# Altium schematic sheet EG4S20BG256.SchDoc(EG4S20BG256)
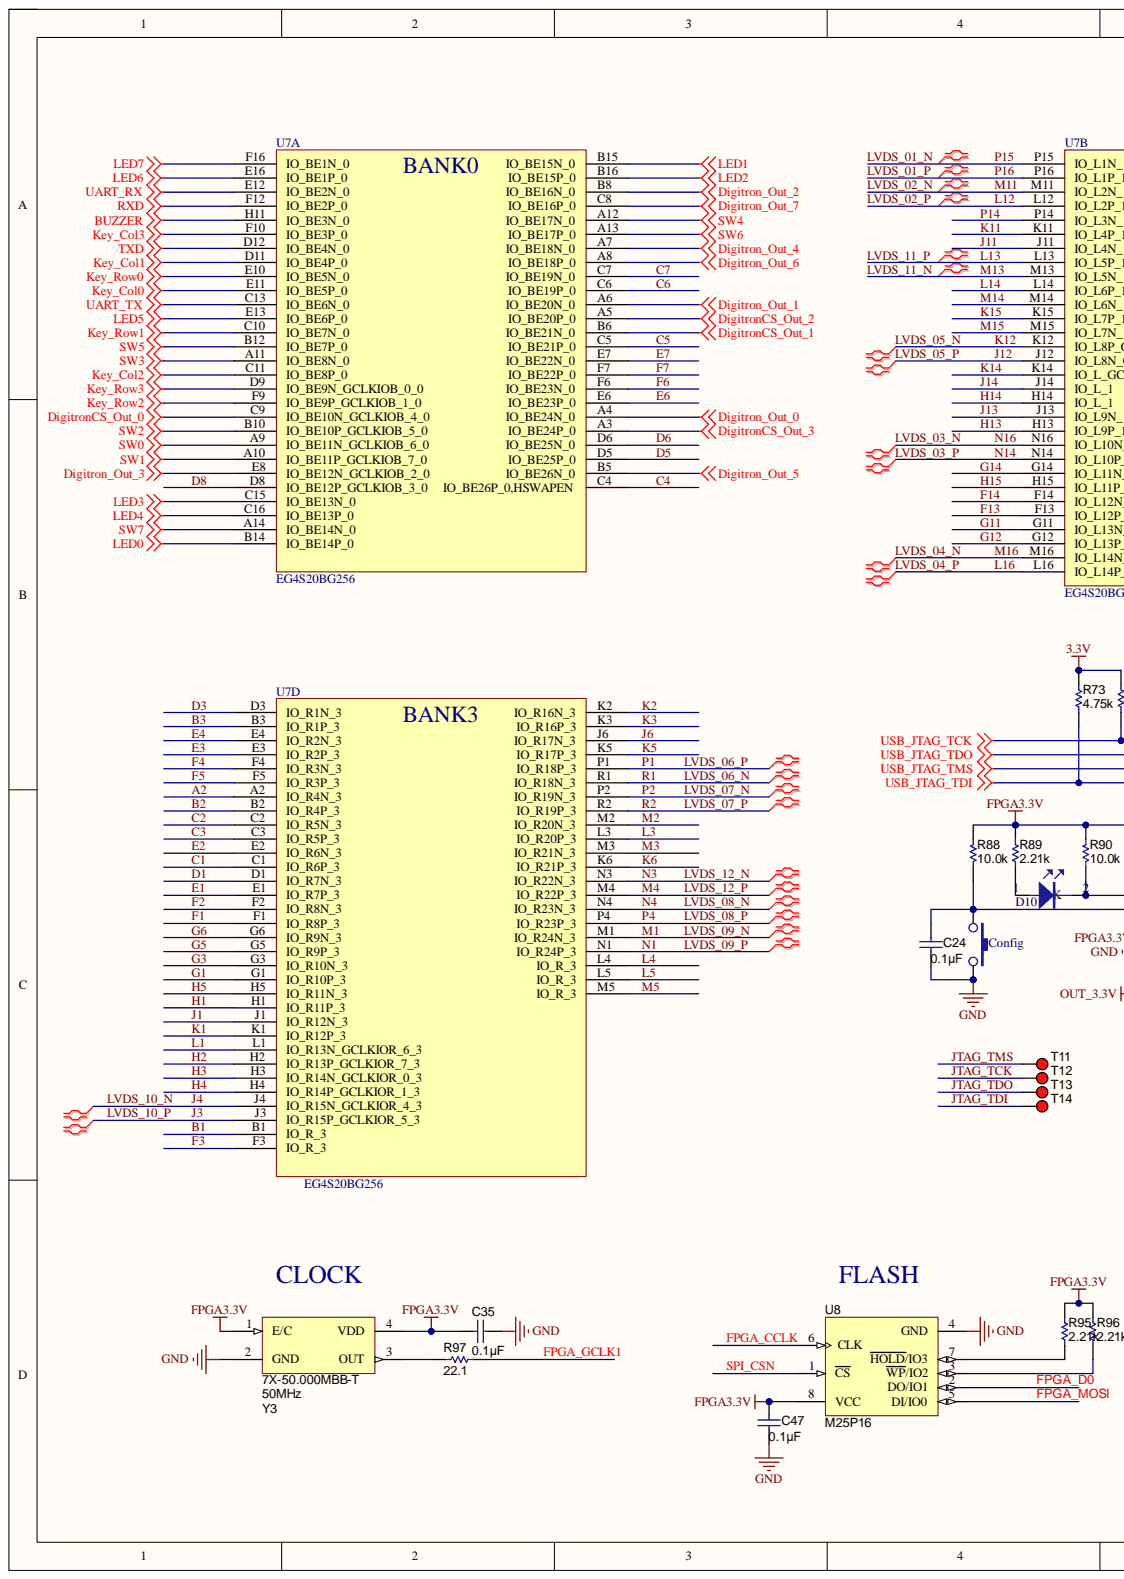
[diagram p0: EG4S20BG256.SchDoc, left]
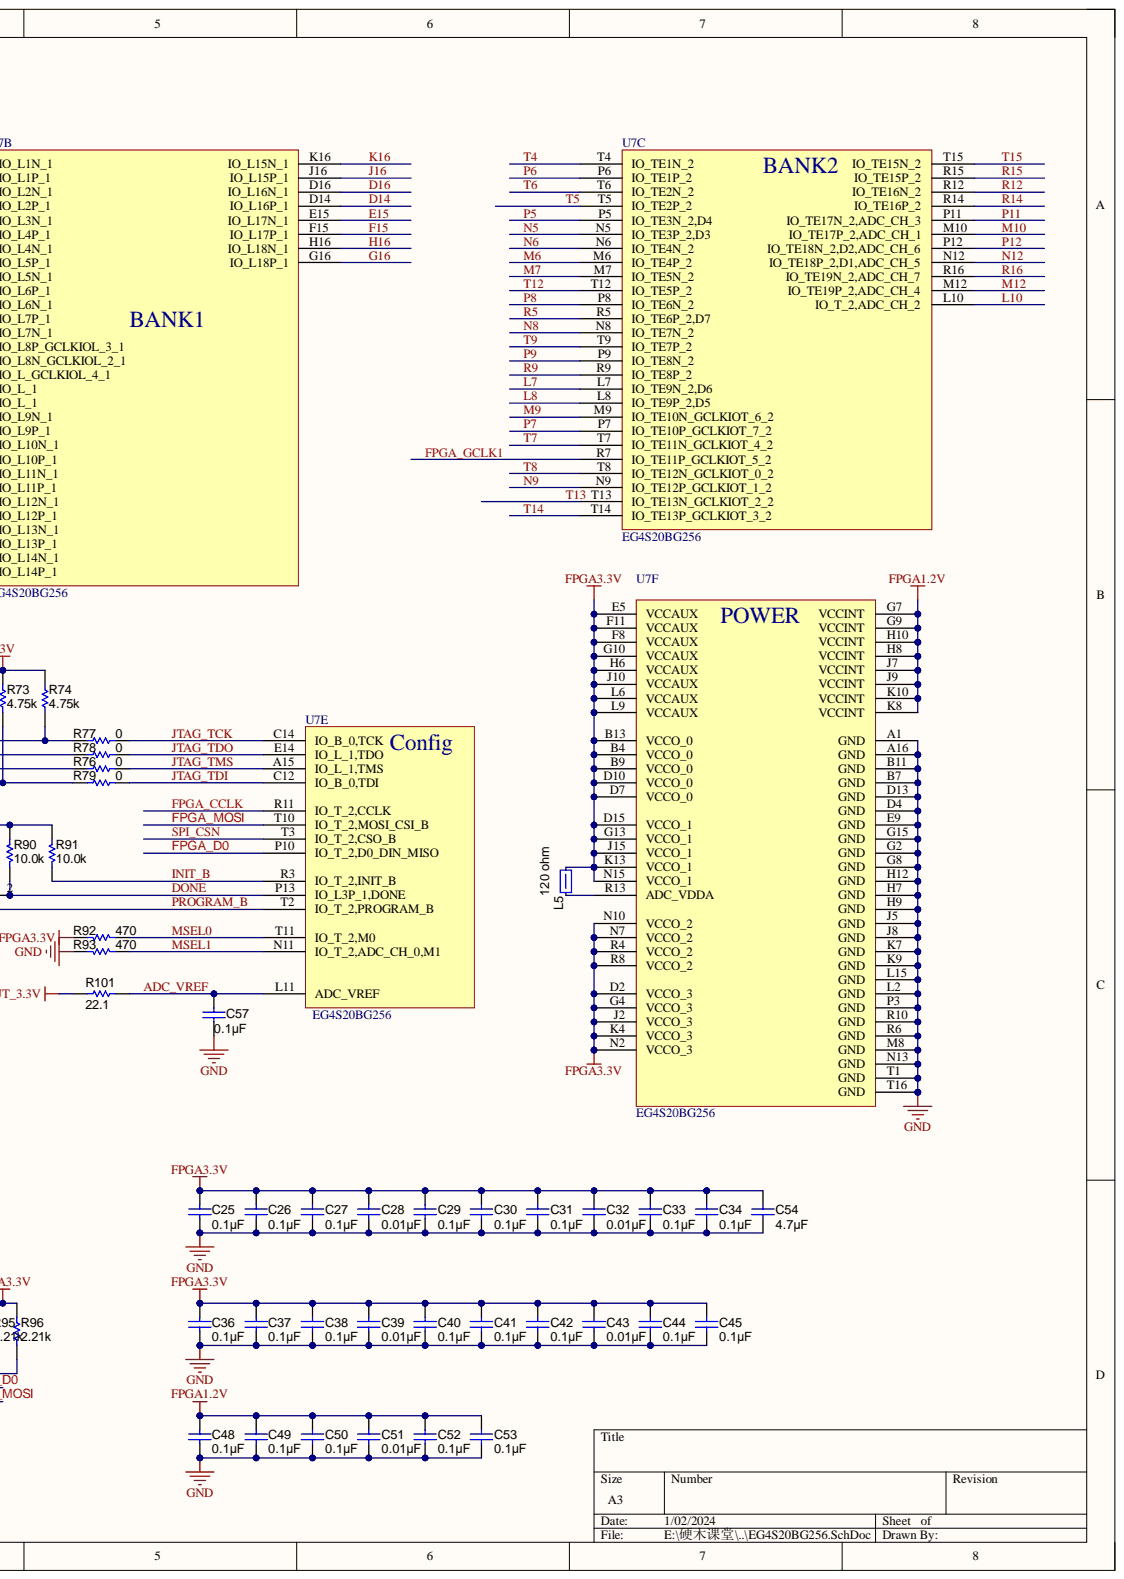
[diagram p1: EG4S20BG256.SchDoc, right]

## Parts
C24  ValueDisplayed: 0.1µF  [0402]  (hidden comment: C1005X7R1H104M)
C25  ValueDisplayed: 0.1μF  [0402]  (hidden comment: C1005X7R1H104M)
C26  ValueDisplayed: 0.1μF  [0402]  (hidden comment: C1005X7R1H104M)
C27  ValueDisplayed: 0.1μF  [0402]  (hidden comment: C1005X7R1H104M)
C28  ValueDisplayed: 0.01μF  [0402]  (hidden comment: C0402C103J5RACTU)
C29  ValueDisplayed: 0.1μF  [0402]  (hidden comment: C1005X7R1H104M)
C30  ValueDisplayed: 0.1μF  [0402]  (hidden comment: C1005X7R1H104M)
C31  ValueDisplayed: 0.1μF  [0402]  (hidden comment: C1005X7R1H104M)
C32  ValueDisplayed: 0.01μF  [0402]  (hidden comment: C0402C103J5RACTU)
C33  ValueDisplayed: 0.1μF  [0402]  (hidden comment: C1005X7R1H104M)
C34  ValueDisplayed: 0.1μF  [0402]  (hidden comment: C1005X7R1H104M)
C35  ValueDisplayed: 0.1µF  [0402]  (hidden comment: C1005X7R1H104M)
C36  ValueDisplayed: 0.1μF  [0402]  (hidden comment: C1005X7R1H104M)
C37  ValueDisplayed: 0.1μF  [0402]  (hidden comment: C1005X7R1H104M)
C38  ValueDisplayed: 0.1μF  [0402]  (hidden comment: C1005X7R1H104M)
C39  ValueDisplayed: 0.01μF  [0402]  (hidden comment: C0402C103J5RACTU)
C40  ValueDisplayed: 0.1μF  [0402]  (hidden comment: C1005X7R1H104M)
C41  ValueDisplayed: 0.1μF  [0402]  (hidden comment: C1005X7R1H104M)
C42  ValueDisplayed: 0.1μF  [0402]  (hidden comment: C1005X7R1H104M)
C43  ValueDisplayed: 0.01μF  [0402]  (hidden comment: C0402C103J5RACTU)
C44  ValueDisplayed: 0.1μF  [0402]  (hidden comment: C1005X7R1H104M)
C45  ValueDisplayed: 0.1μF  [0402]  (hidden comment: C1005X7R1H104M)
C47  ValueDisplayed: 0.1µF  [0402]  (hidden comment: C1005X7R1H104M)
C48  ValueDisplayed: 0.1μF  [0402]  (hidden comment: C1005X7R1H104M)
C49  ValueDisplayed: 0.1μF  [0402]  (hidden comment: C1005X7R1H104M)
C50  ValueDisplayed: 0.1μF  [0402]  (hidden comment: C1005X7R1H104M)
C51  ValueDisplayed: 0.01μF  [0402]  (hidden comment: C0402C103J5RACTU)
C52  ValueDisplayed: 0.1μF  [0402]  (hidden comment: C1005X7R1H104M)
C53  ValueDisplayed: 0.1μF  [0402]  (hidden comment: C1005X7R1H104M)
C54  ValueDisplayed: 4.7µF  [0805_HV]  (hidden comment: GRM21BC81C475KA88L)
C57  ValueDisplayed: 0.1µF  [0402]  (hidden comment: C1005X7R1H104M)
Config  贴片按键  [BUTTOM_T_2]  (value not drawn)
D10  LED 红色  [LED_LTST-C170]  (value not drawn)
L5  value 120 ohm  [0805_HV]  (hidden comment: GZ2012V121TF)
R73  value 4.75k  [0402]  (hidden comment: CRCW04024K75FKED)
R74  value 4.75k  [0402]  (hidden comment: CRCW04024K75FKED)
R76  value 0  [0402]  (hidden comment: CRCW04020000Z0ED)
R77  value 0  [0402]  (hidden comment: CRCW04020000Z0ED)
R78  value 0  [0402]  (hidden comment: CRCW04020000Z0ED)
R79  value 0  [0402]  (hidden comment: CRCW04020000Z0ED)
R88  value 10.0k  [0402]  (hidden comment: CRCW040210K0FKED)
R89  value 2.21k  [0402]  (hidden comment: CRCW04022K21FKED)
R90  value 10.0k  [0402]  (hidden comment: CRCW040210K0FKED)
R91  value 10.0k  [0402]  (hidden comment: CRCW040210K0FKED)
R92  value 470  [0402]  (hidden comment: CRCW0402470RJNED)
R93  value 470  [0402]  (hidden comment: CRCW0402470RJNED)
R95  value 2.21k  [0402]  (hidden comment: CRCW04022K21FKED)
R96  value 2.21k  [0402]  (hidden comment: CRCW04022K21FKED)
R97  value 22.1  Res_ohm: 22.1  [0402]  (hidden comment: CRCW040222R1FKED)
R101  value 22.1  Res_ohm: 22.1  [0402]  (hidden comment: CRCW040222R1FKED)
T11  5000  [TP]  (value not drawn)
T12  5000  [TP]  (value not drawn)
T13  5000  [TP]  (value not drawn)
T14  5000  [TP]  (value not drawn)
U7  EG4S20BG256  [FBGA256]  (parts A,B,C,D,E,F)
U8  M25P16  [MICT-MW-8_N]
Y3  7X-50.000MBB-T  Frequency: 50MHz  [XTAL_NX3225SA]

## Nets
3.3V: R73.2 R74.2
BUZZER: U7.H11(IO_BE3N_0)
Digitron_Out_0: U7.A4(IO_BE24N_0)
Digitron_Out_1: U7.A6(IO_BE20N_0)
Digitron_Out_2: U7.B8(IO_BE16N_0)
Digitron_Out_3: U7.E8(IO_BE12N_GCLKIOB_2_0)
Digitron_Out_4: U7.A7(IO_BE18N_0)
Digitron_Out_5: U7.B5(IO_BE26N_0)
Digitron_Out_6: U7.A8(IO_BE18P_0)
Digitron_Out_7: U7.C8(IO_BE16P_0)
DigitronCS_Out_0: U7.C9(IO_BE10N_GCLKIOB_4_0)
DigitronCS_Out_1: U7.B6(IO_BE21N_0)
DigitronCS_Out_2: U7.A5(IO_BE20P_0)
DigitronCS_Out_3: U7.A3(IO_BE24P_0)
FPGA1.2V: C48.1 C49.1 C50.1 C51.1 C52.1 C53.1 U7.G7(VCCINT) U7.G9(VCCINT) U7.H8(VCCINT) U7.H10(VCCINT) U7.J7(VCCINT) U7.J9(VCCINT) U7.K8(VCCINT) U7.K10(VCCINT)
FPGA3.3V: C25.1 C26.1 C27.1 C28.1 C29.1 C30.1 C31.1 C32.1 C33.1 C34.1 C35.1 C36.1 C37.1 C38.1 C39.1 C40.1 C41.1 C42.1 C43.1 C44.1 C45.1 C47.2 C54.1 L5.2 R88.2 R89.1 R90.2 R91.2 R92.2 R95.1 R96.1 U7.B4(VCCO_0) U7.B9(VCCO_0) U7.B13(VCCO_0) U7.D2(VCCO_3) U7.D7(VCCO_0) U7.D10(VCCO_0) U7.D15(VCCO_1) U7.E5(VCCAUX) U7.F8(VCCAUX) U7.F11(VCCAUX) U7.G4(VCCO_3) U7.G10(VCCAUX) U7.G13(VCCO_1) U7.H6(VCCAUX) U7.J2(VCCO_3) U7.J10(VCCAUX) U7.J15(VCCO_1) U7.K4(VCCO_3) U7.K13(VCCO_1) U7.L6(VCCAUX) U7.L9(VCCAUX) U7.N2(VCCO_3) U7.N7(VCCO_2) U7.N10(VCCO_2) U7.N15(VCCO_1) U7.R4(VCCO_2) U7.R8(VCCO_2) U8.8(VCC) Y3.1(E/C) Y3.4(VDD)
GND: C24.1 C25.2 C26.2 C27.2 C28.2 C29.2 C30.2 C31.2 C32.2 C33.2 C34.2 C35.2 C36.2 C37.2 C38.2 C39.2 C40.2 C41.2 C42.2 C43.2 C44.2 C45.2 C47.1 C48.2 C49.2 C50.2 C51.2 C52.2 C53.2 C54.2 C57.1 Config.2 R93.2 U7.A1(GND) U7.A16(GND) U7.B7(GND) U7.B11(GND) U7.D4(GND) U7.D13(GND) U7.E9(GND) U7.G2(GND) U7.G8(GND) U7.G15(GND) U7.H7(GND) U7.H9(GND) U7.H12(GND) U7.J5(GND) U7.J8(GND) U7.K7(GND) U7.K9(GND) U7.L2(GND) U7.L15(GND) U7.M8(GND) U7.N13(GND) U7.P3(GND) U7.R6(GND) U7.R10(GND) U7.T1(GND) U7.T16(GND) U8.4(GND) Y3.2(GND)
Key_Col0: U7.E11(IO_BE5P_0)
Key_Col1: U7.D11(IO_BE4P_0)
Key_Col2: U7.C11(IO_BE8P_0)
Key_Col3: U7.F10(IO_BE3P_0)
Key_Row0: U7.E10(IO_BE5N_0)
Key_Row1: U7.C10(IO_BE7N_0)
Key_Row2: U7.F9(IO_BE9P_GCLKIOB_1_0)
Key_Row3: U7.D9(IO_BE9N_GCLKIOB_0_0)
LED0: U7.B14(IO_BE14P_0)
LED1: U7.B15(IO_BE15N_0)
LED2: U7.B16(IO_BE15P_0)
LED3: U7.C15(IO_BE13N_0)
LED4: U7.C16(IO_BE13P_0)
LED5: U7.E13(IO_BE6P_0)
LED6: U7.E16(IO_BE1P_0)
LED7: U7.F16(IO_BE1N_0)
OUT_3.3V: R101.2
RXD: U7.F12(IO_BE2P_0)
SW0: U7.A9(IO_BE11N_GCLKIOB_6_0)
SW1: U7.A10(IO_BE11P_GCLKIOB_7_0)
SW2: U7.B10(IO_BE10P_GCLKIOB_5_0)
SW3: U7.A11(IO_BE8N_0)
SW4: U7.A12(IO_BE17N_0)
SW5: U7.B12(IO_BE7P_0)
SW6: U7.A13(IO_BE17P_0)
SW7: U7.A14(IO_BE14N_0)
TXD: U7.D12(IO_BE4N_0)
UART_RX: U7.E12(IO_BE2N_0)
UART_TX: U7.C13(IO_BE6N_0)
USB_JTAG_TCK: R74.1 R77.2
USB_JTAG_TDI: R73.1 R79.2
USB_JTAG_TDO: R78.2
USB_JTAG_TMS: R76.2
A2: U7.A2(IO_R4N_3)
ADC_VREF: C57.2 R101.1 U7.L11(ADC_VREF)
B1: U7.B1(IO_R_3)
B2: U7.B2(IO_R4P_3)
B3: U7.B3(IO_R1P_3)
C1: U7.C1(IO_R6P_3)
C2: U7.C2(IO_R5N_3)
C3: U7.C3(IO_R5P_3)
C4: U7.C4(IO_BE26P_0,HSWAPEN)
C5: U7.C5(IO_BE21P_0)
C6: U7.C6(IO_BE19P_0)
C7: U7.C7(IO_BE19N_0)
D1: U7.D1(IO_R7N_3)
D3: U7.D3(IO_R1N_3)
D5: U7.D5(IO_BE25P_0)
D6: U7.D6(IO_BE25N_0)
D8: U7.D8(IO_BE12P_GCLKIOB_3_0)
D14: U7.D14(IO_L16P_1)
D16: U7.D16(IO_L16N_1)
DONE: D10.2 R90.1 U7.P13(IO_L3P_1,DONE)
E1: U7.E1(IO_R7P_3)
E2: U7.E2(IO_R6N_3)
E3: U7.E3(IO_R2P_3)
E4: U7.E4(IO_R2N_3)
E6: U7.E6(IO_BE23P_0)
E7: U7.E7(IO_BE22N_0)
E15: U7.E15(IO_L17N_1)
F1: U7.F1(IO_R8P_3)
F2: U7.F2(IO_R8N_3)
F3: U7.F3(IO_R_3)
F4: U7.F4(IO_R3N_3)
F5: U7.F5(IO_R3P_3)
F6: U7.F6(IO_BE23N_0)
F7: U7.F7(IO_BE22P_0)
F13: U7.F13(IO_L12P_1)
F14: U7.F14(IO_L12N_1)
F15: U7.F15(IO_L17P_1)
FPGA_CCLK: U7.R11(IO_T_2,CCLK) U8.6(CLK)
FPGA_D0: U7.P10(IO_T_2,D0_DIN_MISO) U8.2(DO/IO1)
FPGA_GCLK1: R97.2 U7.R7(IO_TE11P_GCLKIOT_5_2)
FPGA_MOSI: U7.T10(IO_T_2,MOSI_CSI_B) U8.5(DI/IO0)
G1: U7.G1(IO_R10P_3)
G3: U7.G3(IO_R10N_3)
G5: U7.G5(IO_R9P_3)
G6: U7.G6(IO_R9N_3)
G11: U7.G11(IO_L13N_1)
G12: U7.G12(IO_L13P_1)
G14: U7.G14(IO_L11N_1)
G16: U7.G16(IO_L18P_1)
H1: U7.H1(IO_R11P_3)
H2: U7.H2(IO_R13P_GCLKIOR_7_3)
H3: U7.H3(IO_R14N_GCLKIOR_0_3)
H4: U7.H4(IO_R14P_GCLKIOR_1_3)
H5: U7.H5(IO_R11N_3)
H13: U7.H13(IO_L9P_1)
H14: U7.H14(IO_L_1)
H15: U7.H15(IO_L11P_1)
H16: U7.H16(IO_L18N_1)
INIT_B: R91.1 U7.R3(IO_T_2,INIT_B)
J1: U7.J1(IO_R12N_3)
J3: U7.J3(IO_R15P_GCLKIOR_5_3)
J4: U7.J4(IO_R15N_GCLKIOR_4_3)
J6: U7.J6(IO_R17N_3)
J11: U7.J11(IO_L4N_1)
J12: U7.J12(IO_L8N_GCLKIOL_2_1)
J13: U7.J13(IO_L9N_1)
J14: U7.J14(IO_L_1)
J16: U7.J16(IO_L15P_1)
JTAG_TCK: R77.1 T12.1 U7.C14(IO_B_0,TCK)
JTAG_TDI: R79.1 T14.1 U7.C12(IO_B_0,TDI)
JTAG_TDO: R78.1 T13.1 U7.E14(IO_L_1,TDO)
JTAG_TMS: R76.1 T11.1 U7.A15(IO_L_1,TMS)
K1: U7.K1(IO_R12P_3)
K2: U7.K2(IO_R16N_3)
K3: U7.K3(IO_R16P_3)
K5: U7.K5(IO_R17P_3)
K6: U7.K6(IO_R21P_3)
K11: U7.K11(IO_L4P_1)
K12: U7.K12(IO_L8P_GCLKIOL_3_1)
K14: U7.K14(IO_L_GCLKIOL_4_1)
K15: U7.K15(IO_L7P_1)
K16: U7.K16(IO_L15N_1)
L1: U7.L1(IO_R13N_GCLKIOR_6_3)
L3: U7.L3(IO_R20P_3)
L4: U7.L4(IO_R_3)
L5: U7.L5(IO_R_3)
L7: U7.L7(IO_TE9N_2,D6)
L8: U7.L8(IO_TE9P_2,D5)
L10: U7.L10(IO_T_2,ADC_CH_2)
L12: U7.L12(IO_L2P_1)
L13: U7.L13(IO_L5P_1)
L14: U7.L14(IO_L6P_1)
L16: U7.L16(IO_L14P_1)
LVDS_01_N: U7.P15(IO_L1N_1)
LVDS_01_P: U7.P16(IO_L1P_1)
LVDS_02_N: U7.M11(IO_L2N_1)
LVDS_03_N: U7.N16(IO_L10N_1)
LVDS_03_P: U7.N14(IO_L10P_1)
LVDS_04_N: U7.M16(IO_L14N_1)
LVDS_06_N: U7.R1(IO_R18N_3)
LVDS_06_P: U7.P1(IO_R18P_3)
LVDS_07_N: U7.P2(IO_R19N_3)
LVDS_07_P: U7.R2(IO_R19P_3)
LVDS_08_N: U7.N4(IO_R23N_3)
LVDS_08_P: U7.P4(IO_R23P_3)
LVDS_09_N: U7.M1(IO_R24N_3)
LVDS_09_P: U7.N1(IO_R24P_3)
LVDS_11_N: U7.M13(IO_L5N_1)
LVDS_12_N: U7.N3(IO_R22N_3)
LVDS_12_P: U7.M4(IO_R22P_3)
M2: U7.M2(IO_R20N_3)
M3: U7.M3(IO_R21N_3)
M5: U7.M5(IO_R_3)
M6: U7.M6(IO_TE4P_2)
M7: U7.M7(IO_TE5N_2)
M9: U7.M9(IO_TE10N_GCLKIOT_6_2)
M10: U7.M10(IO_TE17P_2,ADC_CH_1)
M12: U7.M12(IO_TE19P_2,ADC_CH_4)
M14: U7.M14(IO_L6N_1)
M15: U7.M15(IO_L7N_1)
MSEL0: R92.1 U7.T11(IO_T_2,M0)
MSEL1: R93.1 U7.N11(IO_T_2,ADC_CH_0,M1)
N5: U7.N5(IO_TE3P_2,D3)
N6: U7.N6(IO_TE4N_2)
N8: U7.N8(IO_TE7N_2)
N9: U7.N9(IO_TE12P_GCLKIOT_1_2)
N12: U7.N12(IO_TE18P_2,D1,ADC_CH_5)
P5: U7.P5(IO_TE3N_2,D4)
P6: U7.P6(IO_TE1P_2)
P7: U7.P7(IO_TE10P_GCLKIOT_7_2)
P8: U7.P8(IO_TE6N_2)
P9: U7.P9(IO_TE8N_2)
P11: U7.P11(IO_TE17N_2,ADC_CH_3)
P12: U7.P12(IO_TE18N_2,D2,ADC_CH_6)
P14: U7.P14(IO_L3N_1)
PROGRAM_B: C24.2 Config.1 R88.1 U7.T2(IO_T_2,PROGRAM_B)
R5: U7.R5(IO_TE6P_2,D7)
R9: U7.R9(IO_TE8P_2)
R12: U7.R12(IO_TE16N_2)
R14: U7.R14(IO_TE16P_2)
R15: U7.R15(IO_TE15P_2)
R16: U7.R16(IO_TE19N_2,ADC_CH_7)
SPI_CSN: U7.T3(IO_T_2,CSO_B) U8.1(CS)
T4: U7.T4(IO_TE1N_2)
T5: U7.T5(IO_TE2P_2)
T6: U7.T6(IO_TE2N_2)
T7: U7.T7(IO_TE11N_GCLKIOT_4_2)
T8: U7.T8(IO_TE12N_GCLKIOT_0_2)
T9: U7.T9(IO_TE7P_2)
T12: U7.T12(IO_TE5P_2)
T13: U7.T13(IO_TE13N_GCLKIOT_2_2)
T14: U7.T14(IO_TE13P_GCLKIOT_3_2)
T15: U7.T15(IO_TE15N_2)
NetD10_1: D10.1 R89.2
NetL5_1: L5.1 U7.R13(ADC_VDDA)
NetR95_2: R95.2 U8.7(HOLD/IO3)
NetR96_2: R96.2 U8.3(WP/IO2)
NetR97_1: R97.1 Y3.3(OUT)

## Library data of the parts
C24 C35 C47 C57 = C1005X7R1H104M: CAP, CERM, 0.1 µF, 50 V, +/- 20%, X7R, 0402; Manufacturer: TDK; Cap_F: 0.0000001; CompType: Capacitor; Polarized: N; TaMax_C: 125; TaMin_C: -55; Tolerance_%: 20; U/M: EA; Value: 0.1uF; Value1: Unknown; Value2: 50V; VDC_V: 50; Cap_Case: 0402; Component Kind: Standard; ComponentLink1Description: Datasheet link; LatestRevisionDate: 2015-05-02; Library Name: Capacitor_Ceramic.IntLib; MountType: SMT; PackageReference: 0402; PartNumber: C1005X7R1H104M; Published: 2008-06-19; RoHS: Y; Signal Integrity: Cap; Simulation: Cap; Supplier 1: Digi-Key; Supplier 2: Farnell; Supplier Part Number 1: 445-5933-1-ND; Supplier Part Number 2: 1907278; SymbolVersion: 2008-07-09; Technology: Ceramic; TempCo: X7R; Publisher: Texas Instruments
C25-C27 C29-C31 C33 C34 C36-C38 C40-C42 C44 C45 C48-C50 C52 C53 = C1005X7R1H104M: CAP, CERM, 0.1 μF, 50 V, +/- 20%, X7R, 0402; Manufacturer: TDK; Cap_F: 0.0000001; CompType: Capacitor; Polarized: N; TaMax_C: 125; TaMin_C: -55; Tolerance_%: 20; U/M: EA; Value: 0.1uF; Value1: Unknown; Value2: 50V; VDC_V: 50; Cap_Case: 0402; Component Kind: Standard; ComponentLink1Description: Datasheet link; LatestRevisionDate: 2015-05-02; Library Name: Capacitor_Ceramic.IntLib; MountType: SMT; PackageReference: 0402; PartNumber: C1005X7R1H104M; Published: 2008-06-19; RoHS: Y; Signal Integrity: Cap; Simulation: Cap; Supplier 1: Digi-Key; Supplier 2: Farnell; Supplier Part Number 1: 445-5933-1-ND; Supplier Part Number 2: 1907278; SymbolVersion: 2008-07-09; Technology: Ceramic; TempCo: X7R; Publisher: Texas Instruments
C28 C32 C39 C43 C51 = C0402C103J5RACTU: CAP, CERM, 0.01 μF, 50 V, +/- 5%, X7R, 0402; Manufacturer: Kemet; Cap_F: 0.00000001; CompType: Capacitor; Polarized: N; TaMax_C: 125; TaMin_C: -55; Tolerance_%: 5; U/M: EA; Value: 0.01uF; Value1: Unknown; Value2: 50V; VDC_V: 50; Cap_Case: 0402; Component Kind: Standard; ComponentLink1Description: Datasheet link; ComponentLink1URL: http://www.kemet.com/Lists/ProductCatalog/Attachments/53/KEM_C1002_X7R_SMD.pdf; LatestRevisionDate: 2015-05-02; Library Name: Capacitor_Ceramic.IntLib; MountType: SMT; PackageReference: 0402; PartNumber: C0402C103J5RACTU; Published: 2008-06-19; RoHS: Y; Signal Integrity: Cap; Simulation: Cap; Supplier 1: Digi-Key; Supplier 2: Mouser; Supplier 3: Farnell; Supplier Part Number 1: 399-7758-1-ND; Supplier Part Number 2: 80-C0402C103J5R; Supplier Part Number 3: 2413922; SymbolVersion: 2008-07-09; Technology: Ceramic; TempCo: X7R; Publisher: Texas Instruments
C54 = GRM21BC81C475KA88L: CAP, CERM, 4.7 µF, 16 V, +/- 10%, X6S, 0805; Manufacturer: MuRata; Cap_Case: 0805; Cap_F: 0.0000047; CompType: Capacitor; Polarized: N; TaMax_C: 105; TaMin_C: -55; Tolerance_%: 10; U/M: EA; Value: 4.7uF; Value1: Unknown; Value2: 16V; VDC_V: 16; Component Kind: Standard; ComponentLink1Description: Datasheet link; ComponentLink1URL: http://search.murata.co.jp/Ceramy/PnsearchViewAction.do?HnOFG=ON&HnNFG=ON&SEL_CNT=50&sLang=en&sKey=GRM21BC81C475KA88L&x=9&y=9&search=startwith; LatestRevisionDate: 2015-05-02; Library Name: Capacitor_Ceramic.IntLib; MountType: SMT; PackageReference: 0805; PartNumber: GRM21BC81C475KA88L; Published: 2008-06-19; RoHS: Y; Signal Integrity: Cap; Simulation: Cap; Supplier 1: Digi-Key; Supplier 2: Mouser; Supplier 3: Farnell; Supplier Part Number 1: GRM21BC81C475KA88L-ND; Supplier Part Number 2: 81-GRM21BC81C475KA8L; Supplier Part Number 3: 1889279; SymbolVersion: 2008-07-09; Technology: Ceramic; TempCo: X6S; Publisher: Texas Instruments
Config = SW-PB: 米黄色圆形按键6*6*3.4; Component Kind: Standard
D10 = LED: LED 发光二极管 0805; Component Kind: Standard; Library Name: WK_PCB.SchLib
L5 = BLM21BD121SN1D: Ferrite Bead, 120 ohm @ 100 MHz, 0.2 A, 0805; Manufacturer: MuRata; DCR_ohm: 0.25; Imax_A: 0.2; Value1: 0.25 ohm; Value2: 0.2A; ZTyp@100MHz_Ohm: 120; Component Kind: Standard; ComponentLink1Description: Datasheet link; ComponentLink1URL: http://search.murata.co.jp/Ceramy/image/img/PDF/ENG/L0110S0100BLM21B.pdf; CompType: Ferrite Bead; LatestRevisionDate: 2015-05-01; Library Name: FerriteBead.IntLib; MountType: SMT; PackageReference: 0805; PartNumber: GZ2012V121TF; Published: 2014-04-30; RoHS: Y; Supplier 1: Digi-Key; Supplier 2: Mouser; Supplier 3: Farnell; Supplier Part Number 1: 490-1044-1-ND; Supplier Part Number 2: 81-BLM21BD121SN1D; Supplier Part Number 3: 1515637; SymbolVersion: 2009-06-02; Publisher: Texas Instruments
R73 R74 = CRCW04024K75FKED: RES, 4.75 k, 1%, 0.063 W, 0402; Manufacturer: Vishay-Dale; CompType: Resistor; EIA_Code: E96; Power_W: 0.063; Res_ohm: 4750; TempCo: 100 ppm/C; Tolerance_%: 1; U/M: EA; Value2: 0.063W; Value_Code: 4k75; VDC_V: 50; Component Kind: Standard; ComponentLink1Description: Datasheet link; ComponentLink1URL: http://www.vishay.com/docs/20035/dcrcwe3.pdf; LatestRevisionDate: 2015-05-01; Library Name: Resistor_0402.IntLib; MountType: SMT; PackageReference: 0402; PartNumber: CRCW04024K75FKED; Published: 2008-06-26; RoHS: Y; Signal Integrity: Resistor; Simulation: Resistor; Supplier 1: Digi-Key; Supplier 2: Mouser; Supplier 3: Farnell; Supplier Part Number 1: 541-4.75KLCT-ND; Supplier Part Number 2: 71-CRCW0402-4.75K-E3; Supplier Part Number 3: 1469713; SymbolVersion: 2009-05-29; Technology: Thick Film; Value1: 1%; ValueDisplayed: 4.75k; Publisher: Texas Instruments
R76-R79 = CRCW04020000Z0ED: RES, 0, 5%, 0.063 W, 0402; Manufacturer: Vishay-Dale; CompType: Resistor; EIA_Code: E24; Power_W: 0.063; TempCo: 200 ppm/C; Tolerance_%: 5; U/M: EA; Value2: 0.063W; Value_Code: 0000; VDC_V: 50; Component Kind: Standard; ComponentLink1Description: Datasheet link; ComponentLink1URL: http://www.vishay.com/docs/20035/dcrcwe3.pdf; LatestRevisionDate: 2015-05-01; Library Name: Resistor_0402.IntLib; MountType: SMT; PackageReference: 0402; PartNumber: CRCW04020000Z0ED; Published: 2008-06-26; Res_ohm: 0; RoHS: Y; Signal Integrity: Resistor; Simulation: Resistor; Supplier 1: Digi-Key; Supplier 2: Mouser; Supplier 3: Farnell; Supplier Part Number 1: 541-0.0JCT-ND; Supplier Part Number 2: 71-CRCW0402-0-E3; Supplier Part Number 3: 1469661; SymbolVersion: 2009-05-29; Technology: Thick Film; Value1: 5%; ValueDisplayed: 0; Publisher: Texas Instruments
R88 R90 R91 = CRCW040210K0FKED: RES, 10.0 k, 1%, 0.063 W, 0402; Manufacturer: Vishay-Dale; CompType: Resistor; EIA_Code: E96; Power_W: 0.063; Res_ohm: 10000; TempCo: 100 ppm/C; Tolerance_%: 1; U/M: EA; Value2: 0.063W; Value_Code: 10k0; VDC_V: 50; Component Kind: Standard; ComponentLink1Description: Datasheet link; ComponentLink1URL: http://www.vishay.com/docs/20035/dcrcwe3.pdf; LatestRevisionDate: 2015-05-01; Library Name: Resistor_0402.IntLib; MountType: SMT; PackageReference: 0402; PartNumber: CRCW040210K0FKED; Published: 2008-06-26; RoHS: Y; Signal Integrity: Resistor; Simulation: Resistor; Supplier 1: Digi-Key; Supplier 2: Mouser; Supplier 3: Farnell; Supplier Part Number 1: 541-10.0KLCT-ND; Supplier Part Number 2: 71-CRCW0402-10K-E3; Supplier Part Number 3: 1469669; SymbolVersion: 2009-05-29; Technology: Thick Film; Value1: 1%; ValueDisplayed: 10.0k; Publisher: Texas Instruments
R89 R95 R96 = CRCW04022K21FKED: RES, 2.21 k, 1%, 0.063 W, 0402; Manufacturer: Vishay-Dale; CompType: Resistor; EIA_Code: E96; Power_W: 0.063; Res_ohm: 2210; TempCo: 100 ppm/C; Tolerance_%: 1; U/M: EA; Value2: 0.063W; Value_Code: 2k21; VDC_V: 50; Component Kind: Standard; ComponentLink1Description: Datasheet link; ComponentLink1URL: http://www.vishay.com/docs/20035/dcrcwe3.pdf; LatestRevisionDate: 2015-05-01; Library Name: Resistor_0402.IntLib; MountType: SMT; PackageReference: 0402; PartNumber: CRCW04022K21FKED; Published: 2008-06-26; RoHS: Y; Signal Integrity: Resistor; Simulation: Resistor; Supplier 1: Digi-Key; Supplier 2: Mouser; Supplier 3: Farnell; Supplier Part Number 1: 541-2.21KLCT-ND; Supplier Part Number 2: 71-CRCW0402-2.21K-E3; Supplier Part Number 3: 1469689; SymbolVersion: 2009-05-29; Technology: Thick Film; Value1: 1%; ValueDisplayed: 2.21k; Publisher: Texas Instruments
R92 R93 = CRCW0402470RJNED: RES, 470, 5%, 0.063 W, 0402; Manufacturer: Vishay-Dale; CompType: Resistor; EIA_Code: E24; Power_W: 0.063; TempCo: 200 ppm/C; Tolerance_%: 5; U/M: EA; Value2: 0.063W; Value_Code: 470R; VDC_V: 50; Component Kind: Standard; ComponentLink1Description: Datasheet link; ComponentLink1URL: http://www.vishay.com/docs/20035/dcrcwe3.pdf; LatestRevisionDate: 2015-05-01; Library Name: Resistor_0402.IntLib; MountType: SMT; PackageReference: 0402; PartNumber: CRCW0402470RJNED; Published: 2008-06-26; Res_ohm: 470; RoHS: Y; Signal Integrity: Resistor; Simulation: Resistor; Supplier 1: Digi-Key; Supplier 2: Mouser; Supplier 3: Farnell; Supplier Part Number 1: 541-470JCT-ND; Supplier Part Number 2: 71-CRCW0402J-470-E3; Supplier Part Number 3: 1537425; SymbolVersion: 2009-05-29; Technology: Thick Film; Value1: 5%; ValueDisplayed: 470; Publisher: Texas Instruments
R97 R101 = CRCW040222R1FKED: RES, 22.1, 1%, 0.063 W, 0402; Manufacturer: Vishay-Dale; CompType: Resistor; EIA_Code: E96; Power_W: 0.063; TempCo: 100 ppm/C; Tolerance_%: 1; U/M: EA; Value2: 0.063W; Value_Code: 22R1; VDC_V: 50; Component Kind: Standard; ComponentLink1Description: Datasheet link; ComponentLink1URL: http://www.vishay.com/docs/20035/dcrcwe3.pdf; LatestRevisionDate: 2015-05-01; Library Name: Resistor_0402.IntLib; MountType: SMT; PackageReference: 0402; PartNumber: CRCW040222R1FKED; Published: 2008-06-26; RoHS: Y; Signal Integrity: Resistor; Simulation: Resistor; Supplier 1: Digi-Key; Supplier 2: Mouser; Supplier 3: Farnell; Supplier Part Number 1: 541-22.1LCT-ND; Supplier Part Number 2: 71-CRCW0402-22.1-E3; Supplier Part Number 3: 1469698; SymbolVersion: 2009-05-29; Technology: Thick Film; Value1: 1%; ValueDisplayed: 22.1; Publisher: Texas Instruments
T11-T14 = 5000: Test Point, TH, Miniature, Red; Manufacturer: Keystone; CompType: Test Point Loop; U/M: EA; Component Kind: Standard; ComponentLink1Description: Datasheet Link; ComponentLink1URL: http://www.keyelco.com/pdfs/M55p51.pdf; LatestRevisionDate: 2012-12-26; LatestRevisionNote: Added parts, moved some to Hardware.IntLib; Library Name: Connectors.IntLib; MountType: TH; PackageReference: Keystone5000; PartNumber: 5000; RoHS: Y; Supplier 1: Digi-Key; Supplier Part Number 1: 5000K-ND; SymbolVersion: 2008-04-04; Value: Red; Publisher: Texas Instruments
U8 = W25Q80BLSNIG: 2.5V 8M-Bit Serial Flash Memory with Dual and Quad SPI, SOIC-8; Manufacturer: Winbond; SymbolCreator: Altium; Component Kind: Standard; ComponentLink1Description: Datasheet Link; ComponentLink1URL: http://www.winbond.com/resource-files/da00-w25q80blf1.pdf; LatestRevisionDate: 2015-05-05; Library Name: Other_IC.IntLib; MountType: SMT; PackageReference: SOIC-8; PartNumber: M25P16; RoHS: Y; Supplier 1: Digi-Key; Supplier Part Number 1: W25Q80BLSNIG-ND; SymbolVersion: 2015-04-01; Publisher: Texas Instruments
Y3 = 7X-20.000MBB-T: Crystal, 有源晶振，Sealed Locked 50MHz, 15pF, SMD; Manufacturer: TXC Corporation; CompType: Oscillator; Frequency Stability: ?ppm; SymbolCreator: a0799628; TaMax_C: 85; TaMin_C: -40; U/M: EA; Component Kind: Standard; ComponentLink1Description: Datasheet Link; ComponentLink1URL: http://www.txccrystal.com/images/pdf/7x.pdf; LatestRevisionDate: 2013-06-18; LatestRevisionNote: Changed publisher; MountType: SMT; PackageReference: 3.2x1x2.5 mm; PartNumber: 7X-50.000MBB-T; RoHS: Y; Supplier 1: Digi-Key; Supplier 2: Mouser; Supplier 3: Farnell; Supplier Part Number 1: 887-1217-1-ND; Supplier Part Number 2: 717-7X-20.000MBB-T; Supplier Part Number 3: 1842164; SymbolVersion: 2013-06-14; Library Name: HPA_SCHLIB.SchLib; Publisher: Texas Instruments
U7 = EG4S20BG256: Component Kind: Standard; Library Name: YQPOWER.SchLib
